# Altium schematic sheet BMS Carrier - Current Sense Interface.SchDoc("BMS Carrier - Current Sense Interface")
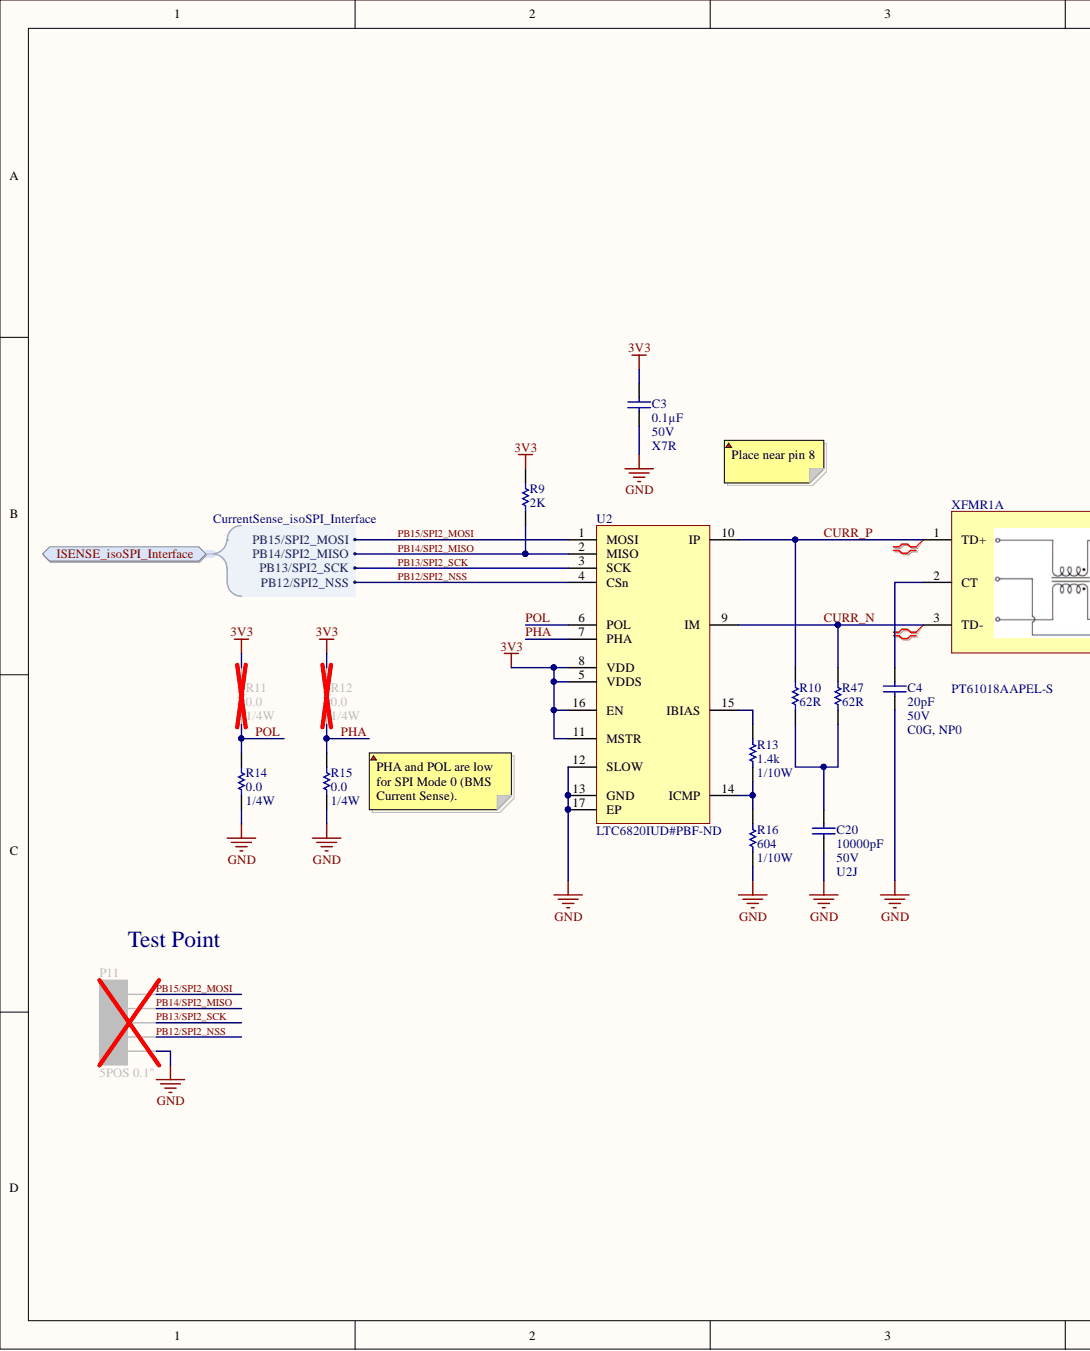
[diagram p0: BMS Carrier - Current Sense Interface.SchDoc, left]
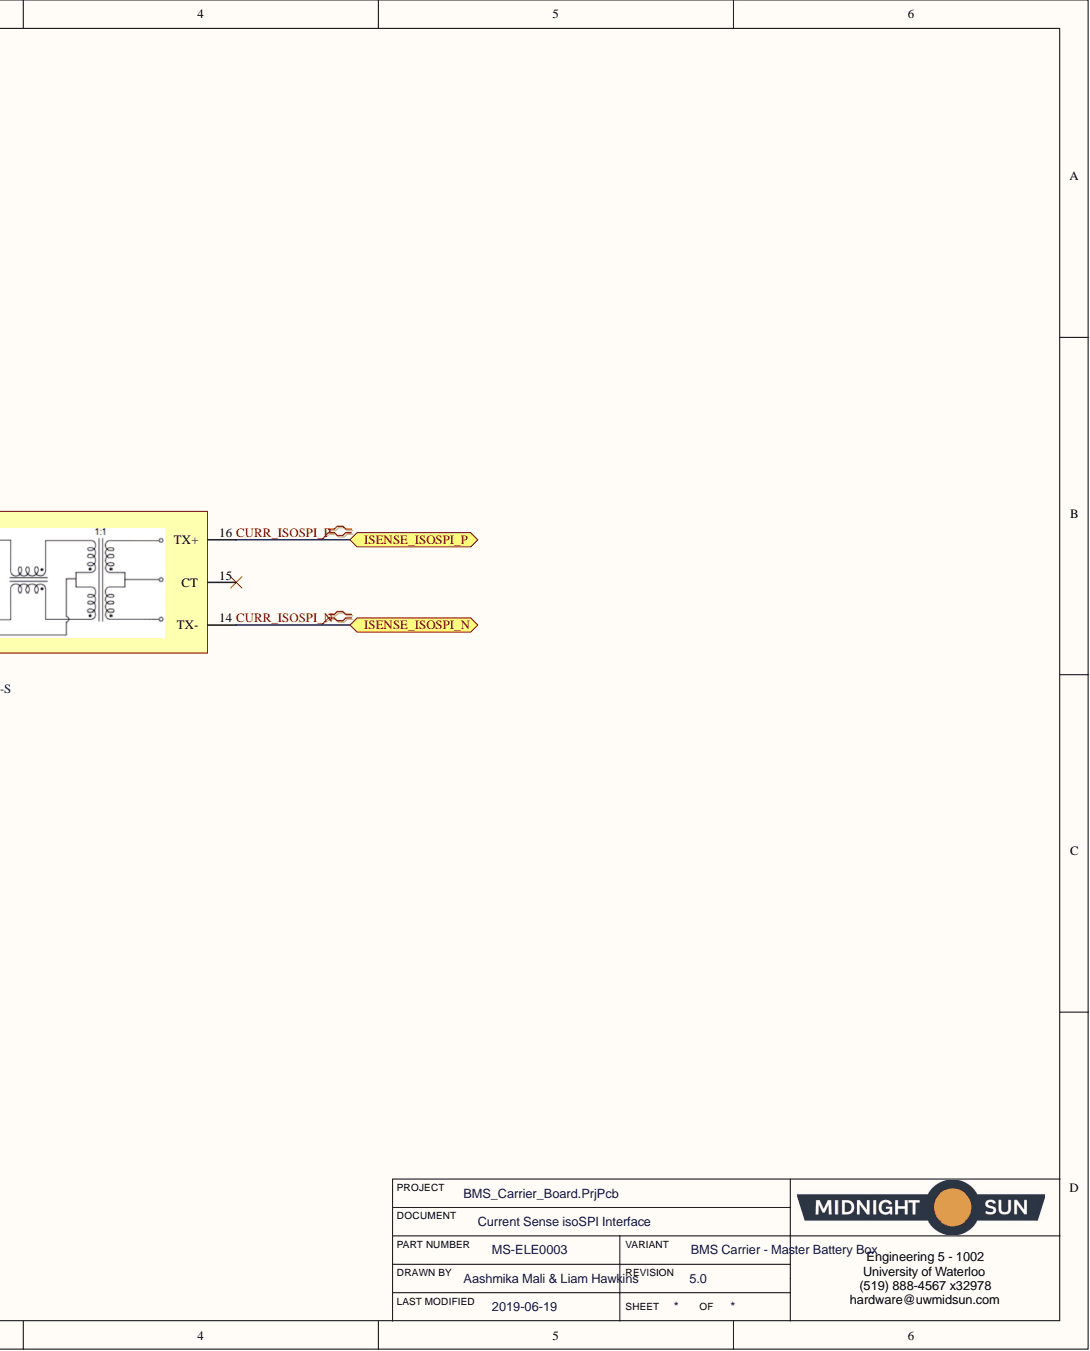
[diagram p1: BMS Carrier - Current Sense Interface.SchDoc, right]

## Parts
C3  Voltage: 50V  Temperature Coefficient: X7R  Capacitance: 0.1µF  [CAP, 0603]  (hidden comment: CAP CER 0.1UF 50V 10% X7R 0603)
C4  Voltage: 50V  Temperature Coefficient: C0G, NP0  Capacitance: 20pF  [CAP, 0603]  (hidden comment: CAP CER 20PF 50V ±5% C0G/NP0 0603)
C20  Voltage: 50V  Temperature Coefficient: U2J  Capacitance: 10000pF  [CAP, 0603]  (hidden comment: CAP CER 10nF 50V 5% X7R 0603)
P11  5POS 0.1"  [CONN, 5POS HEADR 0.1"]
R9  2K  [RES, 0603]
R10  62R  [RES, 0603]
R11  Resistance: 0.0  Power (Watts): 1/4W  [RES, 0603]  (hidden comment: RES 0.0 OHM 1/4W 0603)
R12  Resistance: 0.0  Power (Watts): 1/4W  [RES, 0603]  (hidden comment: RES 0.0 OHM 1/4W 0603)
R13  Resistance: 1.4k  Power: 1/10W  [RES, 0603]  (hidden comment: RES 1.4k OHM 1% 1/10W 0603)
R14  Resistance: 0.0  Power (Watts): 1/4W  [RES, 0603]  (hidden comment: RES 0.0 OHM 1/4W 0603)
R15  Resistance: 0.0  Power (Watts): 1/4W  [RES, 0603]  (hidden comment: RES 0.0 OHM 1/4W 0603)
R16  Power: 1/10W  [RES, 0603]  (hidden comment: RES 604 OHM 1% 1/10W 0603)
R47  62R  [RES, 0603]
U2  Supplier Part Number 1: LTC6820IUD#PBF-ND  [IC COMM INTERFACE ISOSPI 16-QFN]  (hidden comment: IC ISOSPI COMM INTERFACE LTC6820IUD)
XFMR1  MFG_PN: PT61018AAPEL-S  [IC, PULSE XFMR 1CT:1CT 350UH SMD]  (hidden comment: IC PULSE XFMR 1CT:1CT 350UH SMD)  (part A)

## Ports
ISENSE_isoSPI_Interface, ISENSE_isoSPI_Interface.PB12/SPI2_NSS, ISENSE_isoSPI_Interface.PB13/SPI2_SCK, ISENSE_isoSPI_Interface.PB14/SPI2_MISO, ISENSE_isoSPI_Interface.PB15/SPI2_MOSI, ISENSE_ISOSPI_N, ISENSE_ISOSPI_P

## Nets
3V3: C3.1 R9.1 R11.1 R12.1 U2.5(VDDS) U2.8(VDD) U2.11(MSTR) U2.16(EN)
GND: C3.2 C4.2 C20.2 P11.5 R14.2 R15.2 R16.2 U2.12(SLOW) U2.13(GND) U2.17(EP)
CURR_ISOSPI_N: XFMR1.14(TX-) ; port ISENSE_ISOSPI_N
CURR_ISOSPI_P: XFMR1.16(TX+) ; port ISENSE_ISOSPI_P
CURR_N: R47.1 U2.9(IM) XFMR1.3(TD-)
CURR_P: R10.1 U2.10(IP) XFMR1.1(TD+)
PB12/SPI2_NSS: P11.4 U2.4(CSn) ; port ISENSE_isoSPI_Interface
PB13/SPI2_SCK: P11.3 U2.3(SCK) ; port ISENSE_isoSPI_Interface
PB14/SPI2_MISO: P11.2 R9.2 U2.2(MISO) ; port ISENSE_isoSPI_Interface
PB15/SPI2_MOSI: P11.1 U2.1(MOSI) ; port ISENSE_isoSPI_Interface
PHA: R12.2 R15.1 U2.7(PHA)
POL: R11.2 R14.1 U2.6(POL)
NetC4_1: C4.1 XFMR1.2(CT)
NetC20_1: C20.1 R10.2 R47.2
NetR13_1: R13.1 U2.15(IBIAS)
NetR13_2: R13.2 R16.1 U2.14(ICMP)

Not connected: XFMR1 15(CT)

## Library data of the parts
C3 = CAP CER 0.1UF 50V 10% X7R 0603: 0.10µF ±10% 50V Ceramic Capacitor X7R 0603 (1608 Metric); Manufacturer Part Number 1: 06035C104KAT2A; Manufacturer 1: AVX Corporation; Tolerance: ±10%; Thickness (Max): 0.035" (0.90mm); Supplier Part Number 1: 478-5052-1-ND; Supplier 1: Digi-Key; Size / Dimension: 0.063" L x 0.032" W (1.60mm x 0.81mm); Ratings: -; Part Status: Active; Packaging: Cut Tape (CT); Package / Case: 0603 (1608 Metric); Operating Temperature: -55°C ~ 125°C; Mounting Type: Surface Mount, MLCC; Lead Style: -; Lead Spacing: -; Height - Seated (Max): -; ComponentLink1URL: http://datasheets.avx.com/X7RDielectric.pdf; ComponentLink1Description: http://datasheets.avx.com/X7RDielectric.pdf; Component Kind: Standard; Library Name: Schematic Diagrams.SchLib
C4 = CAP CER 20PF 50V ±5% C0G/NP0 0603: 20pF ±5% 50V Ceramic Capacitor C0G, NP0 0603 (1608 Metric); Manufacturer Part Number 1: GRM1885C1H200JA01D; Manufacturer 1: Murata Electronics North America; Tolerance: ±5%; Thickness (Max): 0.035" (0.90mm); Supplier Part Number 1: 490-1410-1-ND; Supplier 1: Digi-Key; Size / Dimension: 0.063" L x 0.031" W (1.60mm x 0.80mm); Ratings: -; Part Status: Active; Packaging: Cut Tape (CT); Package / Case: 0603 (1608 Metric); Operating Temperature: -55°C ~ 125°C; Mounting Type: Surface Mount, MLCC; Lead Style: -; Lead Spacing: -; Height - Seated (Max): -; ComponentLink1URL: http://www.murata.com/~/media/webrenewal/support/library/catalog/products/capacitor/mlcc/c02e.ashx; ComponentLink1Description: http://www.murata.com/~/media/webrenewal/support/library/catalog/products/capacitor/mlcc/c02e.ashx; Component Kind: Standard; Library Name: Schematic Diagrams.SchLib
C20 = CAP CER 10nF 50V 5% X7R 0603: 10000pF ±5% 50V Ceramic Capacitor U2J 0603 (1608 Metric); Manufacturer Part Number 1: C0603C103J5JAC7867; Manufacturer 1: KEMET; Tolerance: ±5%; Thickness (Max): 0.034" (0.87mm); Supplier Part Number 1: 399-13384-1-ND; Supplier 1: Digi-Key; Size / Dimension: 0.063" L x 0.032" W (1.60mm x 0.80mm); Ratings: -; Part Status: Active; Packaging: Cut Tape (CT); Package / Case: 0603 (1608 Metric); Operating Temperature: -55°C ~ 125°C; Mounting Type: Surface Mount, MLCC; Lead Style: -; Lead Spacing: -; Height - Seated (Max): -; Failure Rate: -; ComponentLink1URL: http://www.kemet.com/docfinder?Partnumber=C0603C103J5JAC7867; ComponentLink1Description: http://www.kemet.com/docfinder?Partnumber=C0603C103J5JAC7867; Component Kind: Standard; Library Name: Schematic Diagrams.SchLib
P11 = CONN 5POS HEADR MALE 0.1": Manufacturer Part Number 1: 0022284050; Manufacturer 1: Molex; Pitch: 2.54mm; Number of Positions: 5; Max Voltage Rating (DC): 250V; Gender: Male; Description 1: Conn Unshrouded Header HDR 5 POS 2.54mm Solder ST Thru-Hole KK® Bag; Contact Resistance: 20mR; Connector Type: Male Pin; Termination: Solder; Supplier Part Number 1: WM50014-05-ND; Supplier 1: Digi-Key; Stock 1: 3161; Pricing 1: 1=0.22, 10=0.21, 25=0.1796, 50=0.157, 100=0.1459, 250=0.129, 500=0.1234 (USD); Packaging: Bulk; Orientation: Straight; Number of Rows: 1; Number of Contacts: 5; Mount: Through Hole; Min Operating Temperature: 0°C; Max Voltage Rating (AC): 250V; Max Operating Temperature: 75°C; Length: 12.7mm; Housing Material: Nylon; Housing Colour: Black; Depth: 2.49mm; Contact Plating: Nickel,Tin; Contact Mating Length: 0.24inch; Contact Material: Bronze,Brass; ComponentLink3URL: https://datasheet.ciiva.com/15217/getdatasheetpartid-256138-15217709.pdf; ComponentLink3Description: https://datasheet.ciiva.com/15217/getdatasheetpartid-256138-15217709.pdf; ComponentLink2URL: https://datasheet.ciiva.com/14804/179390022284050-pcb-headers-14804361.pdf; ComponentLink2Description: https://datasheet.ciiva.com/14804/179390022284050-pcb-headers-14804361.pdf; ComponentLink1URL: https://datasheet.ciiva.com/6814/022284363-sd-6814049.pdf; ComponentLink1Description: https://datasheet.ciiva.com/6814/022284363-sd-6814049.pdf; Component Kind: Standard; Colour: Black; Library Name: Schematic Diagrams.SchLib
R9 = RES 2K OHM 1% 1/10W 0603: 2k Ohm ±1% 0.1W, 1/10W Chip Resistor 0603 (1608 Metric) Moisture Resistant Thick Film; Manufacturer Part Number: RC0603FR-072KL; Manufacturer: Yageo; Temperature Coefficient: ±100ppm/°C; Tolerance: ±1%; Supplier Part Number 1: 311-2.00KHRCT-ND; Supplier Device Package: 0603; Supplier 1: Digi-Key; Stock 1: 1750701; Size / Dimension: 0.063" L x 0.031" W (1.60mm x 0.80mm); RoHS: RoHS Compliant; Resistance (Ohms): 2k; Pricing 1: 1=0.15, 10=0.023, 100=0.0093, 1000=0.00415, 2500=0.0036 (CAD); Power (Watts): 0.1W, 1/10W; Part Status: Active; Packaging: Cut Tape (CT); Package / Case: 0603 (1608 Metric); Operating Temperature: -55°C ~ 155°C; Height - Seated (Max): 0.022" (0.55mm); Features: Moisture Resistant; Failure Rate: -; Composition: Thick Film; ComponentLink1URL: http://www.yageo.com/documents/recent/PYu-RC_Group_51_RoHS_L_7.pdf; ComponentLink1Description: http://www.yageo.com/documents/recent/PYu-RC_Group_51_RoHS_L_7.pdf; Component Kind: Standard; Category: Resistors; Library Name: Schematic Diagrams.SchLib
R10 R47 = RES 62 OHM 0.1% 1/10W 0603: 62 Ohms ±0.1% 0.1W, 1/10W Chip Resistor 0603 (1608 Metric) Automotive AEC-Q200 Thin Film; Manufacturer Part Number: ERA-3AEB620V; Manufacturer: Panasonic Electronic Components; Temperature Coefficient: ±25ppm/°C; Tolerance: ±0.1%; Supplier Part Number 1: P62DBCT-ND; Supplier Device Package: 0603; Supplier 1: Digi-Key; Stock 1: 10320; Size / Dimension: 0.063" L x 0.032" W (1.60mm x 0.80mm); RoHS: RoHS Compliant; Pricing 1: 1=0.52, 10=0.447, 100=0.1748, 1000=0.07317, 2500=0.06708 (CAD); Power (Watts): 0.1W, 1/10W; Part Status: Active; Packaging: Cut Tape (CT); Package / Case: 0603 (1608 Metric); Operating Temperature: -55°C ~ 155°C; Height - Seated (Max): 0.022" (0.55mm); Features: Automotive AEC-Q200; Failure Rate: -; Composition: Thin Film; ComponentLink1URL: https://industrial.panasonic.com/cdbs/www-data/pdf/RDM0000/AOA0000C307.pdf; ComponentLink1Description: https://industrial.panasonic.com/cdbs/www-data/pdf/RDM0000/AOA0000C307.pdf; Component Kind: Standard; Category: Resistors; Library Name: Schematic Diagrams.SchLib
R11 R12 R14 R15 = RES 0.0 OHM 1/4W 0603: RES SMD 0.0 OHM JUMPER 1/4W 0603; Manufacturer Part Number 1: CRCW06030000Z0EAHP; Manufacturer 1: Vishay Dale; Supplier Part Number 1: 541-0.0SBCT-ND; Supplier Device Package: 0603; Supplier 1: Digi-Key; Size / Dimension: 0.063" L x 0.033" W (1.60mm x 0.85mm); Part Status: Active; Packaging: Cut Tape (CT); Package / Case: 0603 (1608 Metric); Operating Temperature: -55°C ~ 155°C; Height - Seated (Max): 0.022" (0.55mm); Failure Rate: -; Composition: Thick Film; ComponentLink1URL: http://www.vishay.com/docs/20043/crcwhpe3.pdf; ComponentLink1Description: http://www.vishay.com/docs/20043/crcwhpe3.pdf; Component Kind: Standard; Library Name: Schematic Diagrams.SchLib
R13 = RES 1.4k OHM 1% 1/10W 0603: RES SMD 1.4K OHM 1% 1/10W 0603; Manufacturer Part Number 1: RC0603FR-071K4L; Manufacturer 1: Yageo; Temperature Coefficient: ±100ppm/°C; Tolerance: ±1%; Supplier Part Number 1: 311-1.40KHRCT-ND; Supplier Device Package: 0603; Supplier 1: Digi-Key; Size / Dimension: 0.063" L x 0.031" W (1.60mm x 0.80mm); Part Status: Active; Packaging: Cut Tape (CT); Package / Case: 0603 (1608 Metric); Operating Temperature: -55°C ~ 155°C; Height - Seated (Max): 0.022" (0.55mm); Failure Rate: -; Composition: Thick Film; ComponentLink1URL: http://www.yageo.com/documents/recent/PYu-RC_Group_51_RoHS_L_7.pdf; ComponentLink1Description: http://www.yageo.com/documents/recent/PYu-RC_Group_51_RoHS_L_7.pdf; Component Kind: Standard; Library Name: Schematic Diagrams.SchLib
R16 = RES 604 OHM 1% 1/10W 0603: RES SMD 604 OHM 1% 1/10W 0603; Manufacturer Part Number 1: RC0603FR-07604RL; Manufacturer 1: Yageo; Temperature Coefficient: ±100ppm/°C; Resistance: 604; Tolerance: ±1%; Supplier Part Number 1: 311-604HRCT-ND; Supplier Device Package: 0603; Supplier 1: Digi-Key; Size / Dimension: 0.063" L x 0.031" W (1.60mm x 0.80mm); Part Status: Active; Packaging: Cut Tape (CT); Package / Case: 0603 (1608 Metric); Operating Temperature: -55°C ~ 155°C; Height - Seated (Max): 0.022" (0.55mm); Failure Rate: -; Composition: Thick Film; ComponentLink1URL: http://www.yageo.com/documents/recent/PYu-RC_Group_51_RoHS_L_7.pdf; ComponentLink1Description: http://www.yageo.com/documents/recent/PYu-RC_Group_51_RoHS_L_7.pdf; Component Kind: Standard; Library Name: Schematic Diagrams.SchLib
U2 = IC ISOSPI COMM INTERFACE LTC6820IUD: IC COMM INTERFACE ISOSPI 16-QFN; Manufacturer Part Number: LTC6820IUD#PBF; Manufacturer: Linear Technology; Voltage - Supply: 2.7 V ~ 5.5 V; Interface: SPI; Supplier Device Package: 16-QFN (3x3); Supplier 1: Digi-Key; Stock 1: 827; RoHS: RoHS Compliant; Pricing 1: 1=7.35, 25=4.8816, 100=4.0066 (CAD); Part Status: Active; Packaging: Tube; Package / Case: 16-WFQFN Exposed Pad; Mounting Type: Surface Mount; ComponentLink1URL: http://www.linear.com/docs/42317; ComponentLink1Description: http://www.linear.com/docs/42317; Component Kind: Standard; Category: Integrated Circuits (ICs); Applications: Isolated Communications Interface; Library Name: Schematic Diagrams.SchLib
XFMR1 = IC PULSE XFMR 1CT:1CT 350UH SMD: PULSE XFMR 1CT:1CT 350UH SMD; Manufacturer: Bourns Inc.; Isolation Voltage: 1.5kVrms; Supplier Part Number 1: PT61018AAPEL-SCT-ND; Supplier_PN: PT61018AAPEL-SCT-ND; Supplier 1: Digi-Key; Supplier: Digikey; Inductance: 350uH; Component Kind: Standard; Library Name: Schematic Diagrams.SchLib
